# Altium schematic sheet OPA.SchDoc
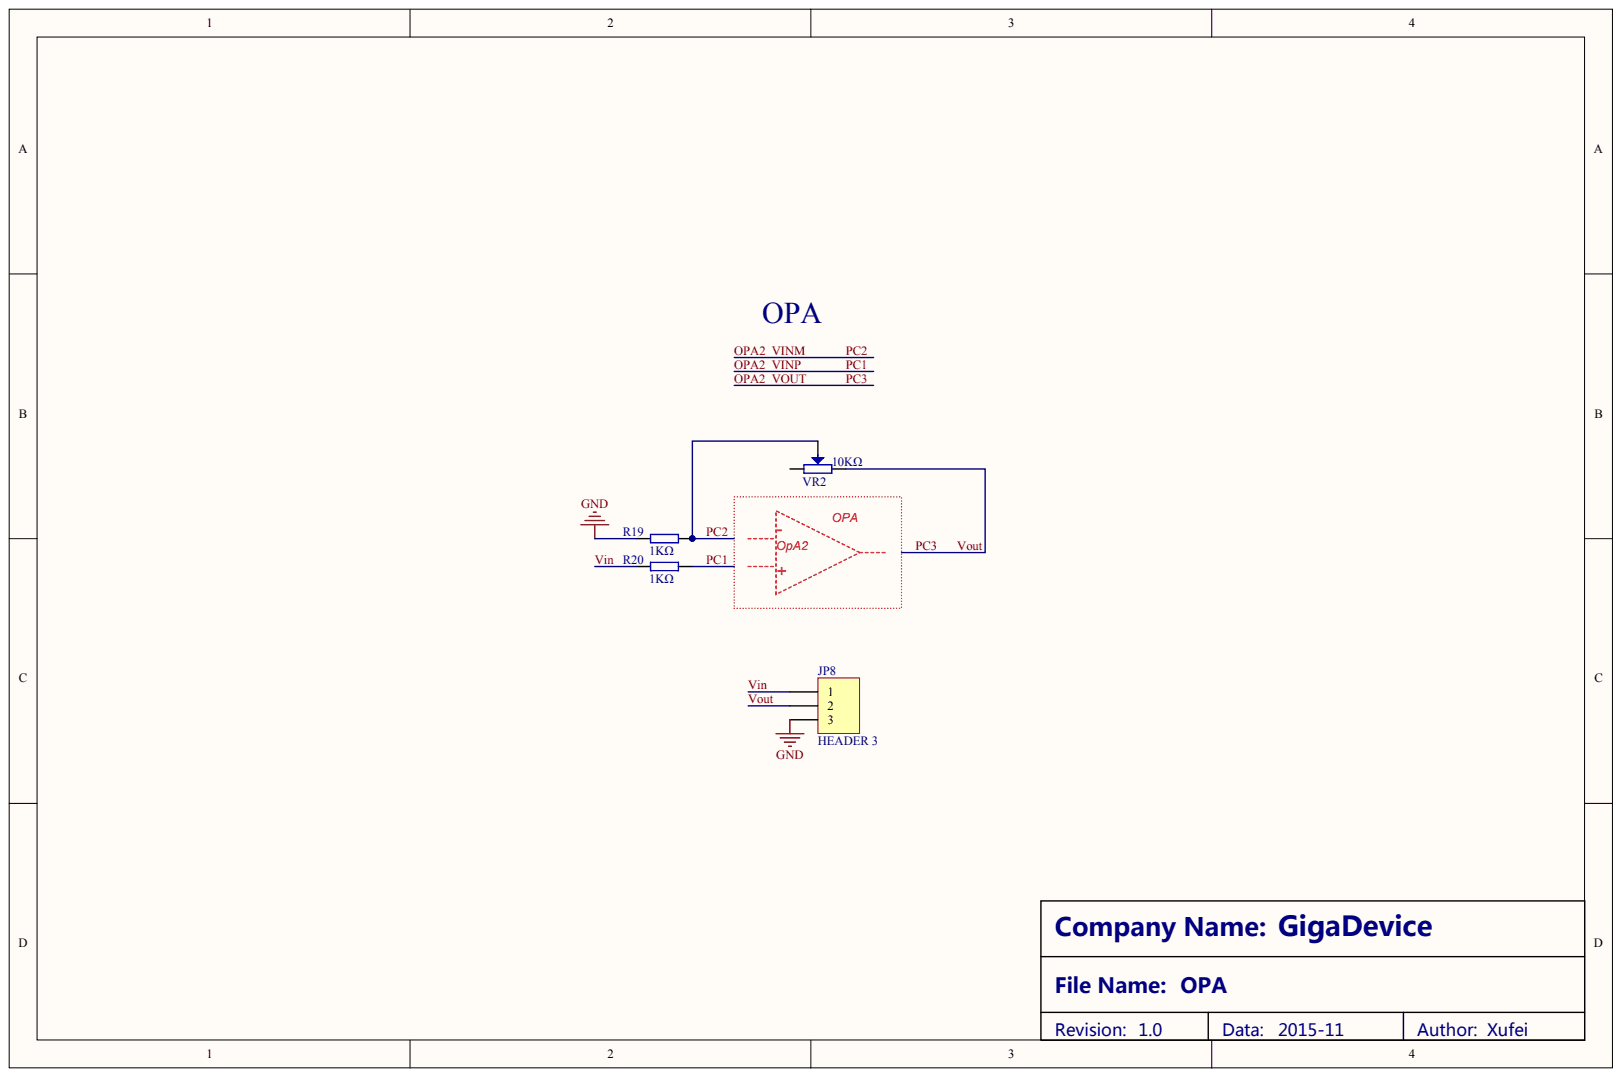
[diagram p0: OPA.SchDoc]

## Parts
JP8  HEADER 3
R19  1KΩ
R20  1KΩ
VR2  10KΩ

## Nets
GND: JP8.3 R19.2
OPA2_VINM: R19.1 VR2.2
OPA2_VINP: R20.1
OPA2_VOUT: JP8.2 VR2.3
VIN: JP8.1 R20.2

Not connected: VR2 1
